# Altium schematic sheet ratopus.schdoc(ratopus)
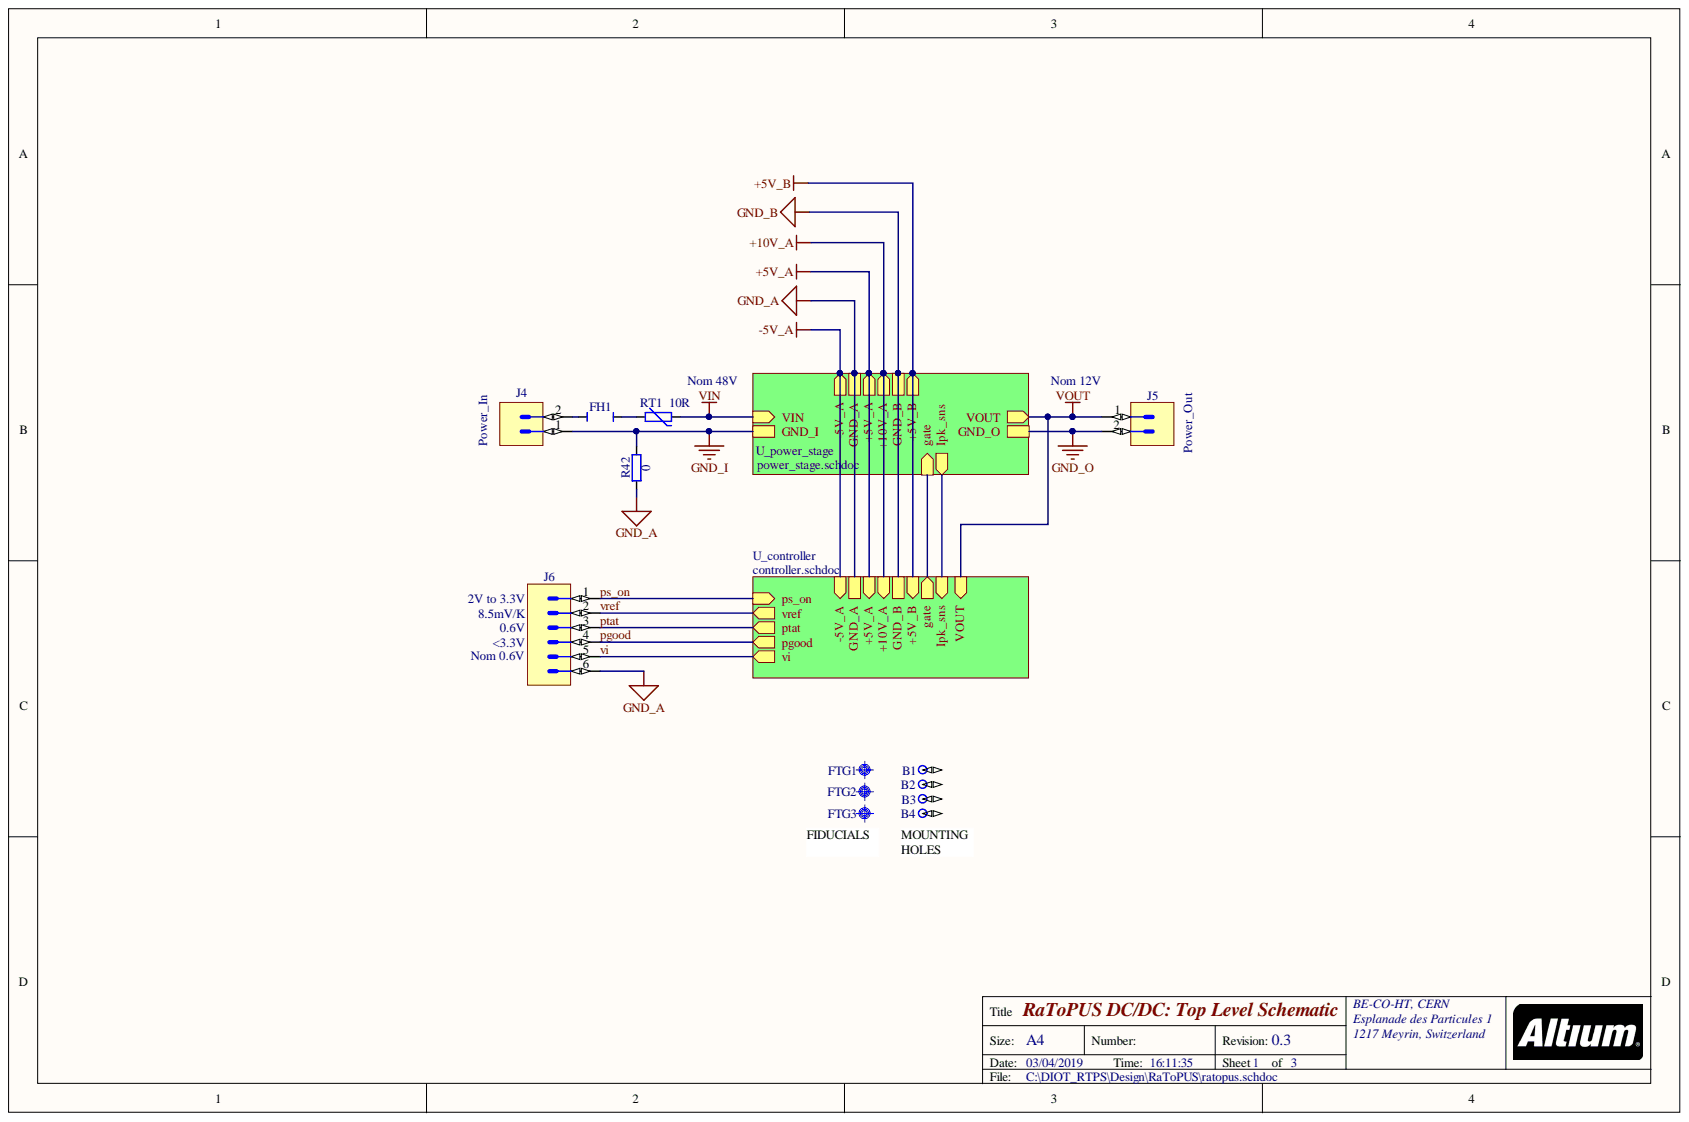
[diagram p0: ratopus.schdoc]

## Parts
B1  Non Plated Hole  [MTG270]  (value not drawn)  (no BOM)
B2  Non Plated Hole  [MTG270]  (value not drawn)  (no BOM)
B3  Non Plated Hole  [MTG270]  (value not drawn)  (no BOM)
B4  Non Plated Hole  [MTG270]  (value not drawn)  (no BOM)
FH1  Fuse Holder  [FUSH_SCHURTER_0031.7601]  (value not drawn)
FTG1  Use only in PCB  [FIDUCIAL_TOP_C100-200]  (value not drawn)  (no BOM)
FTG2  Use only in PCB  [FIDUCIAL_TOP_C100-200]  (value not drawn)  (no BOM)
FTG3  Use only in PCB  [FIDUCIAL_TOP_C100-200]  (value not drawn)  (no BOM)
J4  Family  [PHOENIX_1755736]  (value not drawn)
J5  Family  [PHOENIX_1755736]  (value not drawn)
J6  Header 6  [JST_B6B-XH-A(LF)(SN)]  (value not drawn)
R42  0  [RESC2012X60N]
RT1  10R  [THERM_TDK_B57236S0]

## Nets
GND_A: J6.6 R42.1
GND_I: J4.1 R42.2
GND_O: J5.2
VIN: RT1.2
VOUT: J5.1
pgood: J6.4
ps_on: J6.1
ptat: J6.3
vi: J6.5
vref: J6.2
NetB1_1: B1.1
NetB2_1: B2.1
NetB3_1: B3.1
NetB4_1: B4.1
NetFH1_1: FH1.1 J4.2
NetFH1_2: FH1.2 RT1.1
+5V_A:
+5V_B:
+10V_A:
-5V_A:
gate:
GND_B:
Ipk_sns:

## Library data of the parts
B1-B4 = NON-PLATED_HOLE2.7: Single Terminal Socket; Part Description: Non Plated Through Hole: Hole Dia.=2.7mm; Mounted: No; Database Table Name: Pads; Status: None; Socket: No; SMD: No; Sense: No; Pin Count: 1; Part Number: NON-PLATED_HOLE2.7; PackageDescription: Non Plated Through Hole: Hole Dia.=2.7mm; Library Name: Eletro-mechanical.DbLib; LatestRevisionDate: 11/11/2015; HelpURL: Undefined; Family: Non Plated Hole; CreateDate: 11/11/2015; Component Type: Standard (No BOM); ComponentHeight: 0mm; Author: CERN DEM BC
FH1 = FUSH_SCHURTER_0031.7601: Part Description: Vertical Fuse Holder For D8.5 x H8.5mm Subminiature Fuses; PressFit: No; Database Table Name: Fuses; Socket: No; SMD: No; Sense: No; Pin Count: 2; Part Number: FUSH_SCHURTER_0031.7601; PackageDescription: Vertical Fuse Holder For D8.5x H 8.5mm Subminiature Fuses; Mounted: Yes; Manufacturer Part Number: 0031.7601; Manufacturer: SCHURTER; Library Name: Eletro-mechanical.DbLib; LatestRevisionDate: 12/09/2012; HelpURL: \\cern.ch\dfs\Applications\Altium\Datasheets\FUSH_SCHURTER_0031.7601.pdf; Family: Fuse Holder; Description: Fuse Holder; CreateDate: 12/09/2012; Component Type: Standard; Component Kind: Standard; ComponentHeight: 4.4mm; Author: CERN DEM JLC
FTG1-FTG3 = FIDUCIAL_TARGET_C100-200: Fiducial Target; Part Description: Round Fiducial Target, Top Layer, 1.0mm, Top Solder 2.0mm, Keepout =2.0mm; Mounted: No; Database Table Name: Pads; Status: None; Socket: No; SMD: Yes; Sense: No; Pin Count: 1; Part Number: FIDUCIAL_TARGET_C100-200; PackageDescription: Round Fiducial Target, Top Layer, 1.0mm, Top Solder 2.0mm, Keepout =2.0mm; Library Name: Eletro-mechanical.DbLib; LatestRevisionDate: 04/04/2012; HelpURL: Undefined; Family: Fiducial; CreateDate: 09/02/2010; Component Type: Standard (No BOM); ComponentHeight: 0mm; Author: CERN DEM JLC
J4 J5 = PHOENIX_1755736: Connector 2 Male; Part Description: 12A, 250V, Pitch 5.08mm, 2 Positions Header; Manufacturer Part Number: 1755736 (MSTBVA 2,5/2-G-5,08); Manufacturer: PHOENIX CONTACT; PressFit: No; Status: None; Socket: No; SMD: No; Sense: No; Pin Count: 2; Part Number: PHOENIX_1755736; Mounted: Yes; Library Name: Connectors.DbLib; LatestRevisionDate: 07/12/2010; HelpURL: \\cern.ch\dfs\Applications\Altium\Datasheets\PHOENIX_1755736.pdf; Database Table Name: PHOENIX; CreateDate: 07/12/2010; Component Type: Standard; Component Kind: Standard; ComponentHeight: 12mm; Author: CERN DEM JLC
J6 = JST_B6B-XH-A(LF)(SN): Connector 6 Male; Part Description: 6 Contacts, 2.5mm Pitch, Top Entry Type Shrouded Header; Manufacturer: JST (JAPAN SOLDERLESS TERMINALS); PressFit: No; Database Table Name: Miscellaneous; Status: None; Socket: No; SMD: No; Sense: No; Pin Count: 6; Part Number: JST_B6B-XH-A(LF)(SN); Mounted: Yes; Manufacturer Part Number: B6B-XH-A(LF)(SN); Library Name: Connectors.DbLib; LatestRevisionDate: 26/06/2014; HelpURL: \\cern.ch\dfs\Applications\Altium\Datasheets\JST_B6B-XH-A(LF)(SN).pdf; Family: Header 6; CreateDate: 26/06/2014; Component Type: Standard; Component Kind: Standard; ComponentHeight: 7mm; Author: CERN DEM JLC
R42 = R0805_0R_JUMPER: Resistor; Part Description: 2A (0.05R Max DC Resistance) Zero Ohm Jumper; Manufacturer1 Part Number: NRC10Z0; Manufacturer: GENERIC; PressFit: No; Database Table Name: Resistors SMD; Value: 0; Status: Preferred; Socket: No; SMD: Yes; Sense: No; Pin Count: 2; Part Number: R0805_0R_JUMPER; Mounted: Yes; Manufacturer Part Number: R0805_0R_JUMPER; Manufacturer1 Example: NIC COMPONENT; Manufacturer1 ComponentHeight: 0.6mm; Library Name: Resistors.DbLib; LatestRevisionDate: 13/03/2008; HelpURL: \\cern.ch\dfs\Applications\Altium\Datasheets\R0805_NIC_NRC.pdf; CreateDate: 03/12/2007; Component Type: Standard; Component Kind: Standard; Case: 0805; Author: CERN DEM JLC
RT1 = THERM_NTC_10R_TDK_B57236S0100M000: Thermistor NTC (Negative Temperature Coefficient); Part Description: Radial Leaded NTC Thermistor For Inrush Current Limiting; Manufacturer: TDK; Voltage: 230VAC; Tolerance: ±20%; Power: 3.5A; Database Table Name: Thermistors And Varistors; Value: 10R; Status: None; Socket: No; SMD: No; Sense: No; Pin Count: 2; Part Number: THERM_NTC_10R_TDK_B57236S0100M000; Mounted: Yes; Manufacturer Part Number: B57236S0100M000; Library Name: Resistors.DbLib; LatestRevisionDate: 12/09/2018; HelpURL: \\cern.ch\dfs\Applications\Altium\Datasheets\THERM_NTC_TDK_B57236S0100M000.pdf; CreateDate: 12/09/2018; Component Type: Standard; Component Kind: Standard; ComponentHeight: 16mm; Author: CERN DEM JLC
